# Altium schematic sheet Core.SchDoc(Core)
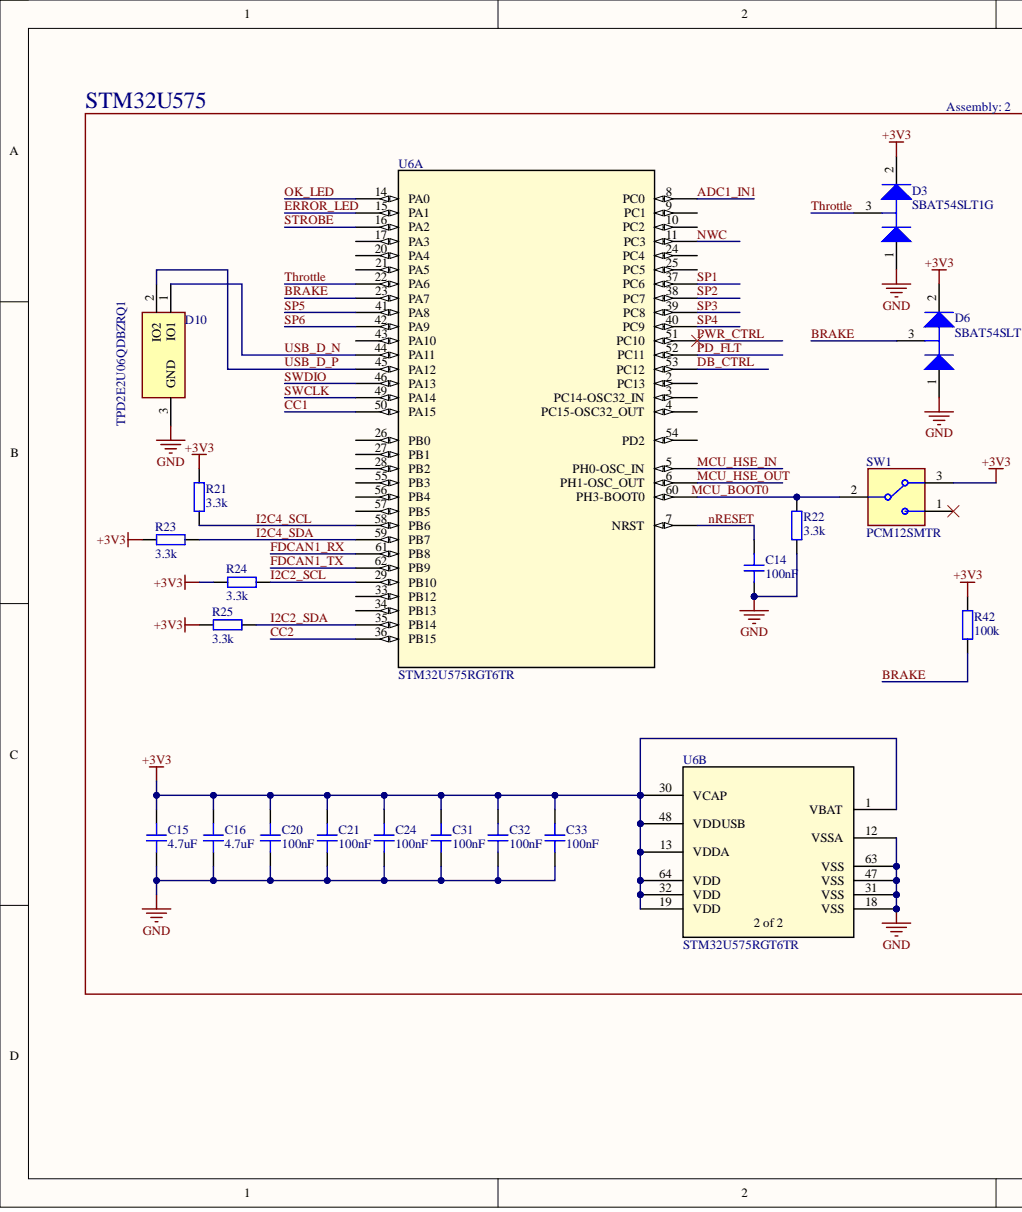
[diagram p0: Core.SchDoc, left]
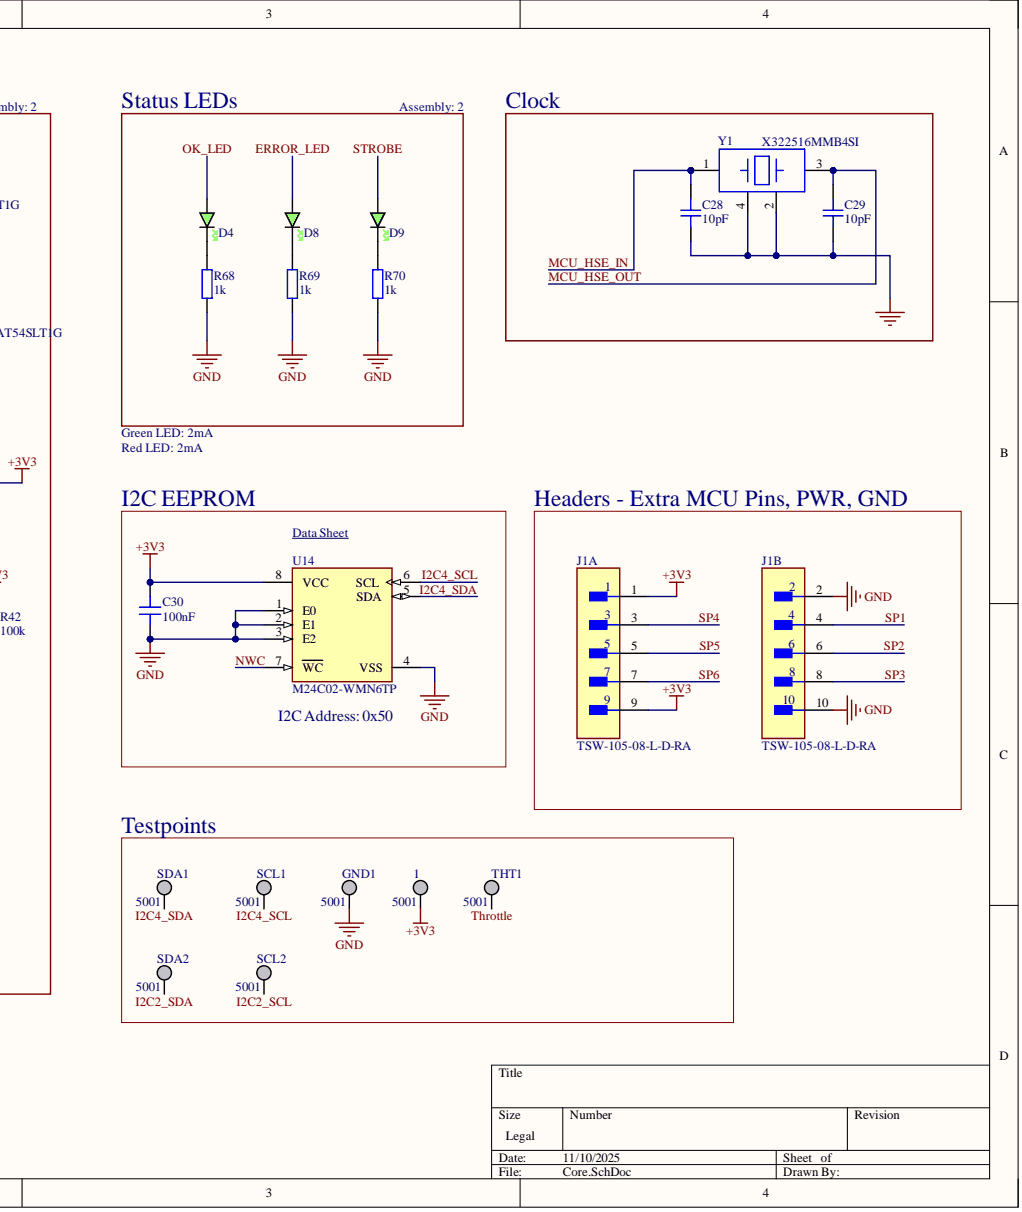
[diagram p1: Core.SchDoc, right]

## Parts
1  5001
C14  100nF
C15  4.7uF
C16  4.7uF
C20  100nF
C21  100nF
C24  100nF
C28  10pF
C29  10pF
C30  value 100nF  (hidden comment: CL05B104KO5NNNC)
C31  100nF
C32  100nF
C33  100nF
D3  SBAT54SLT1G
D4  GREEN  (value not drawn)
D6  SBAT54SLT1G
D8  GREEN  (value not drawn)
D9  GREEN  (value not drawn)
D10  TPD2E2U06QDBZRQ1
GND1  5001
J1  TSW-105-08-L-D-RA  (parts A,B)
R21  3.3k
R22  3.3k
R23  3.3k
R24  3.3k
R25  3.3k
R42  100k
R68  1k
R69  1k
R70  1k
SCL1  5001
SCL2  5001
SDA1  5001
SDA2  5001
SW1  PCM12SMTR
THT1  5001
U6  STM32U575RGT6TR  (parts A,B)
U14  M24C02-WMN6TP  Interface: I2C
Y1  X322516MMB4SI  Load Capacitance: 10pF

## Nets
+3V3: 1.1 C15.2 C16.2 C20.2 C21.2 C24.2 C30.2 C31.2 C32.2 C33.2 D3.2 D6.2 J1.1 J1.9 R21.2 R23.1 R24.1 R25.1 R42.2 SW1.3 U6.1(VBAT) U6.13(VDDA) U6.19(VDD) U6.30(VCAP) U6.32(VDD) U6.48(VDDUSB) U6.64(VDD) U14.8(VCC)
GND: C14.1 C15.1 C16.1 C20.1 C21.1 C24.1 C28.1 C29.1 C30.1 C31.1 C32.1 C33.1 D3.1 D6.1 D10.3 GND1.1 J1.2 J1.10 R22.1 R68.1 R69.1 R70.1 U6.12(VSSA) U6.18(VSS) U6.31(VSS) U6.47(VSS) U6.63(VSS) U14.1(E0) U14.2(E1) U14.3(E2) U14.4(VSS) Y1.2 Y1.4
ADC1_IN1: U6.8(PC0)
BRAKE: D6.3 R42.1 U6.23(PA7)
CC1: U6.50(PA15)
CC2: U6.36(PB15)
DB_CTRL: U6.53(PC12)
ERROR_LED: D8.A U6.15(PA1)
FDCAN1_RX: U6.61(PB8)
FDCAN1_TX: U6.62(PB9)
I2C2_SCL: R24.2 SCL2.1 U6.29(PB10)
I2C2_SDA: R25.2 SDA2.1 U6.35(PB14)
I2C4_SCL: R21.1 SCL1.1 U6.58(PB6) U14.6(SCL)
I2C4_SDA: R23.2 SDA1.1 U6.59(PB7) U14.5(SDA)
MCU_BOOT0: R22.2 SW1.2 U6.60(PH3-BOOT0)
MCU_HSE_IN: C28.2 U6.5(PH0-OSC_IN) Y1.1
MCU_HSE_OUT: C29.2 U6.6(PH1-OSC_OUT) Y1.3
nRESET: C14.2 U6.7(NRST)
NWC: U6.11(PC3) U14.7(WC)
OK_LED: D4.A U6.14(PA0)
PD_FLT: U6.52(PC11)
PWR_CTRL: U6.51(PC10)
SP1: J1.4 U6.37(PC6)
SP2: J1.6 U6.38(PC7)
SP3: J1.8 U6.39(PC8)
SP4: J1.3 U6.40(PC9)
SP5: J1.5 U6.41(PA8)
SP6: J1.7 U6.42(PA9)
STROBE: D9.A U6.16(PA2)
SWCLK: U6.49(PA14)
SWDIO: U6.46(PA13)
Throttle: D3.3 THT1.1 U6.22(PA6)
USB_D_N: D10.1 U6.44(PA11)
USB_D_P: D10.2 U6.45(PA12)
NetD4_K: D4.K R68.2
NetD8_K: D8.K R69.2
NetD9_K: D9.K R70.2

Not connected: SW1 1; U6 2(PC13) 3(PC14-OSC32_IN) 4(PC15-OSC32_OUT) 9(PC1) 10(PC2) 17(PA3) 20(PA4) 21(PA5) 24(PC4) 25(PC5) 26(PB0) 27(PB1) 28(PB2) 33(PB12) 34(PB13) 43(PA10) 54(PD2) 55(PB3) 56(PB4) 57(PB5)

## Library data of the parts
1 GND1 SCL1 SCL2 SDA1 SDA2 THT1 = CMP-026-000000-3: KEYSTONE - 5001 - TEST POINT; Manufacturer_Name: Keystone Electronics; Arrow Part Number: 5001; Arrow Price/Stock: https://www.arrow.com/en/products/5001/keystone-electronics?region=europe; Component Kind: Standard; Datasheet Link: http://www.keyelco.com/product-pdf.cfm?p=1310; Design Item ID: 5001; Manufacturer_Part_Number: 5001; Mouser Part Number: 534-5001; Mouser Price/Stock: https://www.mouser.co.uk/ProductDetail/Keystone-Electronics/5001?qs=q0tsjPZWdm%2FW0vdr%2FwowKg%3D%3D; Source: SamacSys.SchLib; Library Name: Solar Gators
C14 C20 C21 C24 C31-C33 = CAP_0603_16V: Capacitor SMD 0603 16V; Component Kind: Standard; Library Name: Schematic-Lib-PhilsLab.SchLib
C15 C16 = CAP_0805_16V: Capacitor SMD 0805 16V; Component Kind: Standard; LCSC#: C1779; Library Name: Schematic-Lib-PhilsLab.SchLib
C28 C29 = CAP_0603: Capacitor SMD 0603; Component Kind: Standard; Library Name: Schematic-Lib-PhilsLab.SchLib
C30 = CMP-001-00114-1: 100nF 16V 0402 X7R 10% MLCC; Capacitor Type: Ceramic; Case/Package: 0402; Component Kind: Standard; JLCPCB Part #: C1525; Max Operating Temperature: 125°C; Min Operating Temperature: -55°C; Mounting Technology: Surface Mount; Pins: 2; RoHS Compliant: Yes; Tolerance: 10%; Voltage Rating: 16V; Library Name: Solar Gators
D3 D6 = CMP-1055-00533-1: Dual Series Schottky Barrier Diodes, 3-Pin SOT-23, Pb-Free, Tape and Reel; Manufacturer: On Semiconductor; trr Max (ns): 5; Component Kind: Standard; ComponentLink1Description: Manufacturer URL; ComponentLink1URL: http://www.onsemi.com/; ComponentLink2Description: Datasheet; ComponentLink2URL: http://www.onsemi.cn/pub_link/Collateral/BAT54SLT1-D.PDF; ComponentLink3Description: Package Specification; ComponentLink3URL: http://www.onsemi.cn/pub_link/Collateral/318-08.PDF; Configuration: Dual; DatasheetVersion: Rev. 15; Library Name: Altium Content Vault; Mounting Technology: Surface Mount; PackageDescription: 3-Pin Small Outline Transistor, Body 2.92 x 1.3 mm, Pitch 0.95 mm; PackageReference: SOT-23-3-318-08; PackageVersion: Rev. AP, 11/2009; Packing: Tape and Reel; PartNumber: SBAT54SLT1G; RoHS: TRUE
D4 D8 D9 = LED_0603_GREEN: LED 0603 GREEN SMD; Component Kind: Standard; Library Name: Schematic-Lib-PhilsLab.SchLib
D10 = CMP-018-000010-3: USB ESD Protection; Manufacturer_Name: Texas Instruments; Arrow Part Number: TPD2E2U06QDBZRQ1; Arrow Price/Stock: https://www.arrow.com/en/products/tpd2e2u06qdbzrq1/texas-instruments?region=nac; Component Kind: Standard; Datasheet Link: http://www.ti.com/lit/gpn/tpd2e2u06-q1; Design Item ID: TPD2E2U06QDBZRQ1; Height: 0.95mm; Manufacturer_Part_Number: TPD2E2U06QDBZRQ1; Mouser Part Number: 595-TPD2E2U06QDBZRQ1; Mouser Price/Stock: https://www.mouser.co.uk/ProductDetail/Texas-Instruments/TPD2E2U06QDBZRQ1?qs=ADSrZYdIRCdCCkTjX0u0BA%3D%3D; Source: SamacSys.SchLib; Library Name: Solar Gators
J1 = CMP-02766-000089-1: CONN HEADER R/A 10POS 2.54MM; Manufacturer: Samtec; Conn Gender: Male; Conn Orientation: Right Angle; Current Rating: 6.3A; Number Of Contacts: 10; Voltage Rating: 550V; Automotive: No; Category: Conn; Component Kind: Standard; ComponentLink2Description: Datasheet; ComponentLink2URL: http://suddendocs.samtec.com/prints/tsw-xxx-xx-xxx-x-xx-xxx-mkt.pdf; Contact Material: Gold,Nickel; Device Class L1: Connectors; Device Class L2: Headers and Wire Housings; Device Class L3: unset; Digikey Description: CONN HEADER R/A 10POS 2.54MM; Footprint Url: http://suddendocs.samtec.com/prints/tsw-xxx-xx-x-x-xx-xxx-footprint.pdf; Height: 5.69mm; Lead Free: Yes; Library Name: Altium Content Vault; Manufacturer Part Number: TSW-105-08-L-D-RA; Number Of Rows: 2; Octopart: https://octopart.com/tsw-105-08-l-d-ra-samtec-272800; Package: TSW-105-08-L-D-RA; Pitch: 2.54mm; RoHS: TRUE; Temperature: -55°C to +125°C; Temperature Range High: +125°C; Temperature Range Low: -55°C
R21-R25 R42 R68-R70 = RES_0603: Resistor SMD 0603; Component Kind: Standard
  R22 R68-R70: LCSC#: C26010; Library Name: Schematic-Lib-PhilsLab.SchLib
  R21 R23-R25 R42: Library Name: Schematic-Lib-PhilsLab (1).SchLib
SW1 = CMP-011-00013-2: Slide Switch SPDT Surface Mount, Right Angle; MF: C&K; Availability: In Stock; Case/Package: None; Check_prices: https://www.snapeda.com/parts/PCM12SMTR/C%2526K/view-part/?ref=eda; Component Kind: Standard; Component Type: Standard; Design Item ID: PCM12SMTR; MP: PCM12SMTR; Pins: 7; Price: None; Purchase-URL: https://pricing.snapeda.com/search/part/PCM12SMTR/?ref=eda; SnapEDA_Link: https://www.snapeda.com/parts/PCM12SMTR/C%2526K/view-part/?ref=snap; Source: PCM12SMTR.SchLib; Library Name: Solar Gators
U6 = STM32U575RGT6TR: Ultra-low-power with FPU Arm Cortex-M33 MCU with TrustZone, 160 MHz with 1 Mbyte of Flash memory; Manufacturer_Name: STMicroelectronics; Component Kind: Standard; Datasheet: https://www.st.com/resource/en/datasheet/stm32u575ag.pdf; Library Name: STM32U575RGT6TR.SchLib; Manufacturer_Part_Number: STM32U575RGT6TR; RefDes: RefDes; Type: Type; Copyright: Copyright (C) 2025 Ultra Librarian. All rights reserved.
U14 = CMP-2000-05370-2: IC EEPROM 2K I2C 400KHZ 8SO; Manufacturer: STMicroelectronics; Access Time: 900ns; Max Supply Voltage: 5.5V; Memory Size: 2Kbits; Min Supply Voltage: 2.5V; Nominal Supply Current: 0.5-1mA; Standoff Height: 0.1mm; Ambient Temperature Range High: +85°C; Ambient Temperature Range Low: -40°C; Automotive: No; Category: IC; Component Kind: Standard; ComponentLink2Description: Datasheet; ComponentLink2URL: https://www.st.com/resource/en/datasheet/m24c02-w.pdf; Device Class L1: Integrated Circuits (ICs); Device Class L2: Memory; Device Class L3: EEPROM; Digikey Description: IC EEPROM 2K I2C 400KHZ 8SO; Frequency: 400kHz; Height: 1.75mm; Lead Free: Yes; Library Name: Altium Content Vault; Manufacturer Part Number: M24C02-WMN6TP; Memory Type: EEPROM; Mouser Description: M24C02 Series 2 Kb (256 x 8) 2.5 V SMT Serial I²C Bus EEPROM - SOIC-8; Octopart: https://octopart.com/m24c02-wmn6tp-stmicroelectronics-55404516; Package: SO8N-SO-A; RoHS: TRUE; Temperature: -40°C to +85°C; Temperature Range High: +85°C; Temperature Range Low: -40°C
Y1 = CMP-018-000014-3: 16MHz Oscillator 10pF 10ppm 3.2 x 2.5mm; Manufacturer_Name: YXC; Case/Package: 3.2mm x 2.5mm; Component Kind: Standard; Datasheet Link: https://www.we-online.com/catalog/datasheet/830207658809.pdf; Design Item ID: 830207658809; Frequency: 16MHz; Height: 1.2mm; JLCPCB Part #: C112972; Manufacturer_Part_Number: X322516MMB4SI; Mouser Part Number: 710-830207658809; Mouser Price/Stock: https://www.mouser.co.uk/ProductDetail/Wurth-Elektronik/830207658809?qs=hWgE7mdIu5QE%2FCurpvqJsA%3D%3D; Source: SamacSys.SchLib; Library Name: Solar Gators
